# Altium schematic sheet lv_io_accel.SchDoc
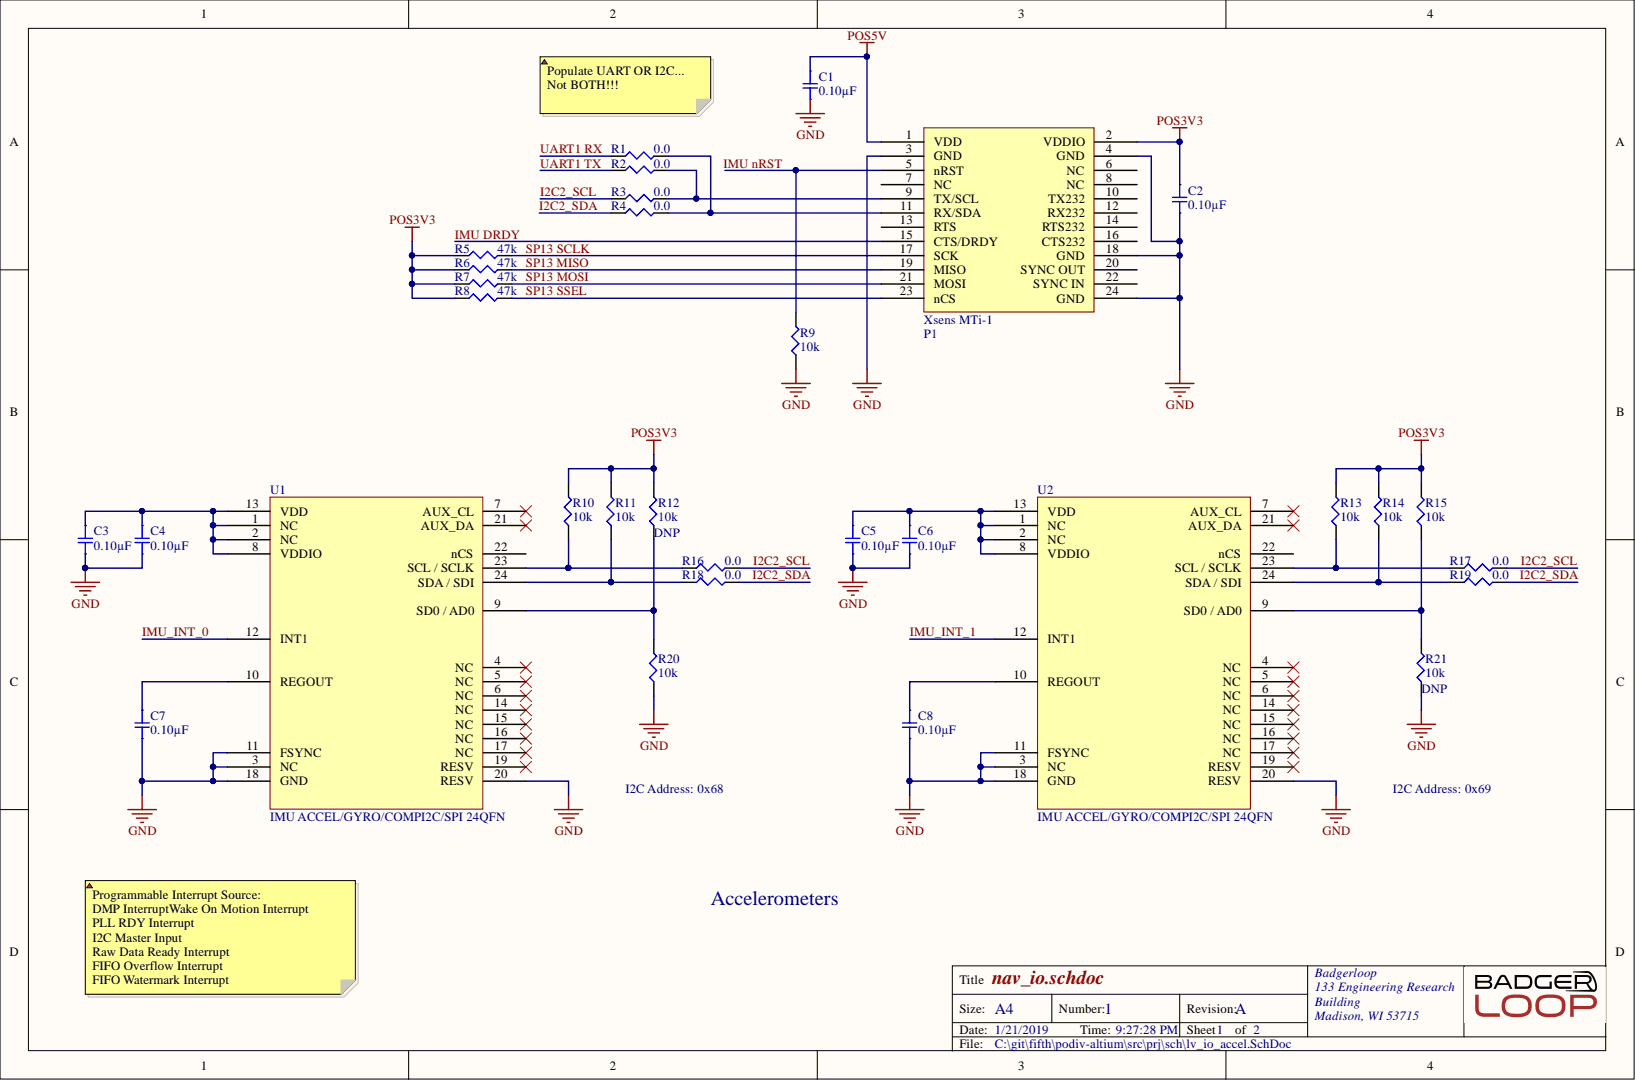
[diagram p0: lv_io_accel.SchDoc]

## Parts
C1  0.10µF  [SMD-0603C]
C2  0.10µF  [SMD-0603C]
C3  0.10µF  [SMD-0603C]
C4  0.10µF  [SMD-0603C]
C5  0.10µF  [SMD-0603C]
C6  0.10µF  [SMD-0603C]
C7  0.10µF  [SMD-0603C]
C8  0.10µF  [SMD-0603C]
P1  Manufacturer: Xsens  [MTI-3]  (hidden comment: XSNS MTI-1)
R1  0.0  [SMD-0402-RES]
R2  0.0  [SMD-0402-RES]
R3  0.0  [SMD-0402-RES]
R4  0.0  [SMD-0402-RES]
R5  47k  [SMD-0402-RES]
R6  47k  [SMD-0402-RES]
R7  47k  [SMD-0402-RES]
R8  47k  [SMD-0402-RES]
R9  10k  [SMD-0402-RES]
R10  10k  [SMD-0402-RES]
R11  10k  [SMD-0402-RES]
R12  10k  [SMD-0402-RES]
R13  10k  [SMD-0402-RES]
R14  10k  [SMD-0402-RES]
R15  10k  [SMD-0402-RES]
R16  0.0  [SMD-0402-RES]
R17  0.0  [SMD-0402-RES]
R18  0.0  [SMD-0402-RES]
R19  0.0  [SMD-0402-RES]
R20  10k  [SMD-0402-RES]
R21  10k  [SMD-0402-RES]
U1  IMU ACCEL/GYRO/COMPI2C/SPI 24QFN  [QFN40P300X300X105_HS-25N]
U2  IMU ACCEL/GYRO/COMPI2C/SPI 24QFN  [QFN40P300X300X105_HS-25N]

## Nets
GND: C1.2 C2.2 C3.2 C4.2 C5.2 C6.2 C7.2 C8.2 P1.3(GND) P1.4(GND) P1.18(GND) P1.24(GND) R9.1 R20.1 R21.1 U1.3(NC) U1.11(FSYNC) U1.18(GND) U1.20(RESV) U2.3(NC) U2.11(FSYNC) U2.18(GND) U2.20(RESV)
POS3V3: C2.1 P1.2(VDDIO) R5.1 R6.1 R7.1 R8.1 R10.2 R11.2 R12.2 R13.2 R14.2 R15.2
POS5V: C1.1 P1.1(VDD)
I2C2_SCL: R3.1 R16.2 R17.2
I2C2_SDA: R4.1 R18.2 R19.2
IMU DRDY: P1.15(CTS/DRDY)
IMU_INT_0: U1.12(INT1)
IMU_INT_1: U2.12(INT1)
SP13 MISO: P1.19(MISO) R6.2
SP13 MOSI: P1.21(MOSI) R7.2
SP13 SCLK: P1.17(SCK) R5.2
SP13 SSEL: P1.23(nCS) R8.2
UART1 RX: R1.1
UART1 TX: R2.1
NetC3_1: C3.1 C4.1 U1.1(NC) U1.2(NC) U1.8(VDDIO) U1.13(VDD)
NetC5_1: C5.1 C6.1 U2.1(NC) U2.2(NC) U2.8(VDDIO) U2.13(VDD)
NetC7_1: C7.1 U1.10(REGOUT)
NetC8_1: C8.1 U2.10(REGOUT)
NetP1_5: P1.5(nRST) R9.2
NetP1_9: P1.9(TX/SCL) R2.2 R3.2
NetP1_11: P1.11(RX/SDA) R1.2 R4.2
NetR10_1: R10.1 R16.1 U1.23(SCL_/_SCLK)
NetR11_1: R11.1 R18.1 U1.24(SDA_/_SDI)
NetR12_1: R12.1 R20.2 U1.9(SD0_/_AD0)
NetR13_1: R13.1 R17.1 U2.23(SCL_/_SCLK)
NetR14_1: R14.1 R19.1 U2.24(SDA_/_SDI)
NetR15_1: R15.1 R21.2 U2.9(SD0_/_AD0)
IMU nRST:

Not connected: P1 6(NC) 7(NC) 8(NC) 10(TX232) 12(RX232) 13(RTS) 14(RTS232) 16(CTS232) 20(SYNC_OUT) 22(SYNC_IN); U1 4(NC) 5(NC) 6(NC) 7(AUX_CL) 14(NC) 15(NC) 16(NC) 17(NC) 19(RESV) 21(AUX_DA) 22(nCS); U2 4(NC) 5(NC) 6(NC) 7(AUX_CL) 14(NC) 15(NC) 16(NC) 17(NC) 19(RESV) 21(AUX_DA) 22(nCS)

## Library data of the parts
C1-C8 = GRM188R72A104KA35J: CAP CER 0.1UF 100V X7R 0603; Manufacturer: Murata Electronics North America; @ qty: 0; Minimum Quantity: 1; Tolerance: ±10%; Unit Price (USD): 0.28; Applications: General Purpose; Component Kind: Standard; Datasheets: http://www.murata.com/~/media/webrenewal/support/library/catalog/products/capacitor/mlcc/c02e.pdf; Digi-Key Part Number: 490-6439-1-ND; Factory Stock: 0; Features: -; Height - Seated (Max): -; Image: //media.digikey.com/Renders/Murata%20Renders/ZRB-SERIES-0402.jpg; Lead Spacing: -; Lead Style: -; Library Name: capacitor.SchLib; Mounting Type: Surface Mount, MLCC; Operating Temperature: -55°C ~ 125°C; Package / Case: 0603 (1608 Metric); Packaging: Cut Tape (CT); Part Number: GRM188R72A104KA35J; Part Status: Active; Quantity Available: 19148; Ratings: -; Series: GRM; Size / Dimension: 0.063" L x 0.031" W (1.60mm x 0.80mm); Temperature Coefficient: X7R; Thickness (Max): 0.035" (0.90mm); Value: 0.10µF; Voltage: 100V
P1 = XSNS MTI-1: Manufacturer P/N: MTI-3-DK; Component Kind: Standard; Digikey Link: https://www.mouser.com/ProductDetail/Xsens/MTI-3-DK?qs=55YtniHzbhBGvlIRYNoxgQ%3d%3d; Digikey P/N: 512-MTI-3-DK; Library Name: ic.SchLib
R1-R4 R16-R19 = ERJ-2GE0R00X: RES SMD 0.0OHM JUMPER 1/10W 0402; Manufacturer: Panasonic Electronic Components; @ qty: 1500; Factory Stock: 0; Minimum Quantity: 1; Power (Watts): 0.1W, 1/10W; Unit Price (USD): 0.00384; Component Kind: Standard; Composition: Thick Film; Datasheets: http://industrial.panasonic.com/www-cgi/jvcr13pz.cgi?E+PZ+3+AOA0001+ERJ2GE0R00X+7+WW; Digi-Key Part Number: P0.0JCT-ND; Failure Rate: -; Features: Automotive AEC-Q200; Height - Seated (Max): 0.016" (0.40mm); Image: //media.digikey.com/Photos/Panasonic%20Photos/ERJ-PA2-Series.jpg; Library Name: resistor.SchLib; Number of Terminations: 2; Operating Temperature: -55°C ~ 155°C; Package / Case: 0402 (1005 Metric); Packaging: Cut Tape (CT); Part Number: ERJ-2GE0R00X; Part Status: Active; Quantity Available: 3152483; Series: ERJ; Size / Dimension: 0.039" L x 0.020" W (1.00mm x 0.50mm); Supplier Device Package: 0402; Temperature Coefficient: -; Tolerance: Jumper; Value: 0.0
R5-R8 = ERJ-2GEJ473X: RES SMD 47K OHM 5% 1/10W 0402; Manufacturer: Panasonic Electronic Components; @ qty: 1500; Factory Stock: 0; Minimum Quantity: 1; Power (Watts): 0.1W, 1/10W; Temperature Coefficient: ±200ppm/°C; Unit Price (USD): 0.00350; Component Kind: Standard; Composition: Thick Film; Datasheets: http://industrial.panasonic.com/www-cgi/jvcr13pz.cgi?E+PZ+3+AOA0001+ERJ2GEJ473X+7+WW; Digi-Key Part Number: P47KJCT-ND; Failure Rate: -; Features: Automotive AEC-Q200; Height - Seated (Max): 0.016" (0.40mm); Image: //media.digikey.com/Photos/Panasonic%20Photos/ERJ-PA2-Series.jpg; Library Name: resistor.SchLib; Number of Terminations: 2; Operating Temperature: -55°C ~ 155°C; Package / Case: 0402 (1005 Metric); Packaging: Cut Tape (CT); Part Number: ERJ-2GEJ473X; Part Status: Active; Quantity Available: 5182645; Series: ERJ; Size / Dimension: 0.039" L x 0.020" W (1.00mm x 0.50mm); Supplier Device Package: 0402; Tolerance: ±5%; Value: 47k
R9-R15 R20 R21 = ERJ-PA2F1002X: RES SMD 10K OHM 1% 1/5W 0402; Manufacturer: Panasonic Electronic Components; @ qty: 1500; Factory Stock: 0; Minimum Quantity: 1; Power (Watts): 0.2W, 1/5W; Temperature Coefficient: ±100ppm/°C; Unit Price (USD): 0.03029; Component Kind: Standard; Composition: Thick Film; Datasheets: https://industrial.panasonic.com/cdbs/www-data/pdf/RDA0000/AOA0000C243.pdf; Digi-Key Part Number: P17230CT-ND; Failure Rate: -; Features: Automotive AEC-Q200, Pulse Withstanding; Height - Seated (Max): 0.016" (0.40mm); Image: //media.digikey.com/Photos/Panasonic%20Photos/ERJ-PA2-Series.jpg; Library Name: resistor.SchLib; Number of Terminations: 2; Operating Temperature: -55°C ~ 155°C; Package / Case: 0402 (1005 Metric); Packaging: Cut Tape (CT); Part Number: ERJ-PA2F1002X; Part Status: Active; Quantity Available: 12292; Series: ERJ; Size / Dimension: 0.039" L x 0.020" W (1.00mm x 0.50mm); Supplier Device Package: 0402; Tolerance: ±1%; Value: 10k
  R12 R21: DNP: DNP
U1 U2 = ICM-20948: Manufacturer: TDK InvenSense; Component Kind: Standard; Description: IMU ACCEL/GYRO/COMPI2C/SPI 24QFN; Digikey Link: https://www.digikey.com/product-detail/en/tdk-invensense/ICM-20948/1428-1123-1-ND/7062698; Digikey P/N: 1428-1123-1-ND; Library Name: ic.SchLib; Manufacturer P/N: ICM-20948
